# Altium schematic sheet DSS.SchDoc(DSS)
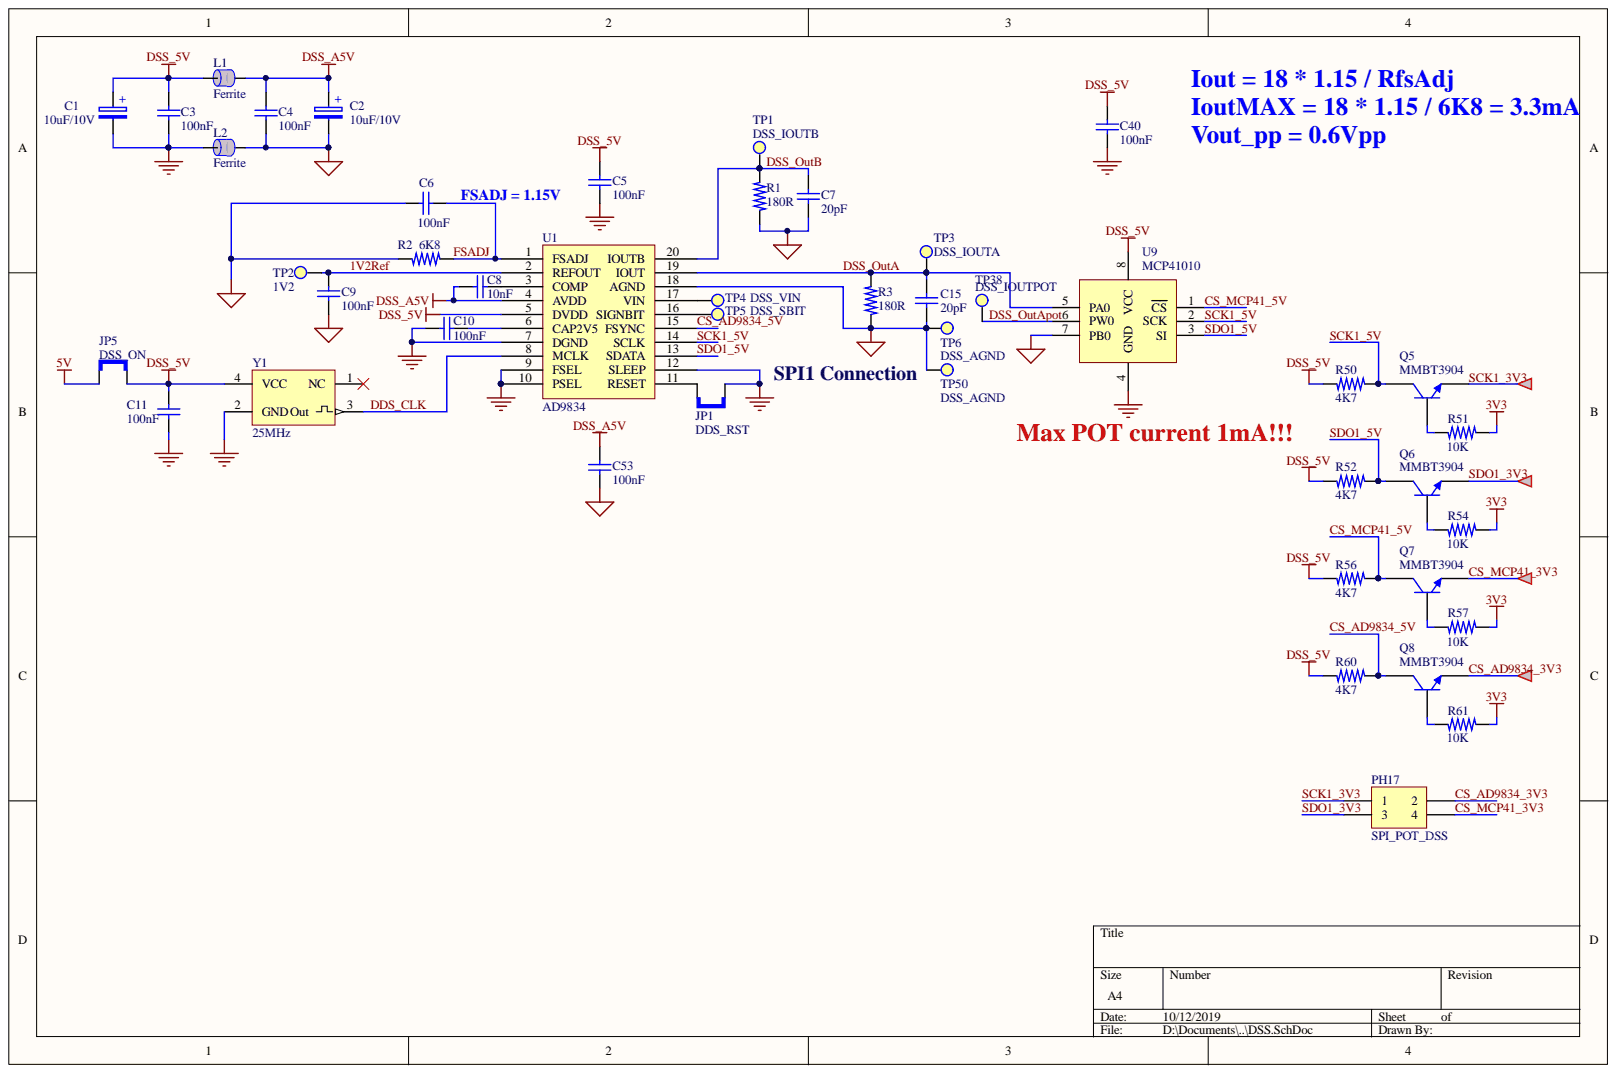
[diagram p0: DSS.SchDoc]

## Parts
C1  10uF/10V  [CAP_RAD_P04_D5x11]
C2  10uF/10V  [CAP_RAD_P04_D5x11]
C3  100nF  [0805Big_C]
C4  100nF  [0805Big_C]
C5  100nF  [0805Big_C]
C6  100nF  [0805Big_C]
C7  20pF  [0805Big_C]
C8  10nF  [0603_C]
C9  100nF  [0603_C]
C10  100nF  [0603_C]
C11  100nF  [0805Big_C]
C15  20pF  [0805Big_C]
C40  100nF  [0603_C]
C53  100nF  [0603_C]
JP1  DDS_RST  [SIP2]
JP5  DSS_ON  [SIP2]
L1  Ferrite  [0805Big_R]
L2  Ferrite  [0805Big_R]
PH17  SPI_POT_DSS  [HDR2X2]
Q5  MMBT3904  [SOT-23]
Q6  MMBT3904  [SOT-23]
Q7  MMBT3904  [SOT-23]
Q8  MMBT3904  [SOT-23]
R1  180R  [0805Big_R]
R2  6K8  [0805Big_R]
R3  180R  [0805Big_R]
R50  4K7  [0805Big_R]
R51  10K  [0805Big_R]
R52  4K7  [0805Big_R]
R54  10K  [0805Big_R]
R56  4K7  [0805Big_R]
R57  10K  [0805Big_R]
R60  4K7  [0805Big_R]
R61  10K  [0805Big_R]
TP1  DSS_IOUTB  [PIN0MM9]
TP2  1V2  [PIN0MM9]
TP3  DSS_IOUTA  [PIN0MM9]
TP4  DSS_VIN  [PIN0MM9]
TP5  DSS_SBIT  [PIN0MM9]
TP6  DSS_AGND  [PIN0MM9]
TP38  DSS_IOUTPOT  [PIN0MM9]
TP50  DSS_AGND  [PIN0MM9]
U1  AD9834  [TSSOP-20]
U9  MCP41010  [DIP-8]
Y1  25MHz  [DIL-8]

## Nets
3V3: R51.1 R54.1 R57.1 R61.1
5V: JP5.1
DSS_5V: C1.1 C3.1 C5.1 C11.1 C40.1 JP5.2 L1.1 R50.2 R52.2 R56.2 R60.2 U1.5(DVDD) U9.8(VCC) Y1.4(VCC)
DSS_A5V: C2.1 C4.1 C8.1 C53.1 L1.2 U1.4(AVDD)
DSS_AGND: C2.2 C4.2 C6.2 C7.2 C9.2 C15.2 C53.2 L2.2 R1.2 R2.1 R3.2 TP6.1 TP50.1 U1.18(AGND) U9.7(PB0)
GND: C1.2 C3.2 C5.2 C10.1 C11.2 C40.2 JP1.1 L2.1 U1.7(DGND) U1.9(FSEL) U1.10(PSEL) U1.12(SLEEP) U9.4(GND) Y1.2
1V2Ref: C9.1 TP2.1 U1.2(REFOUT)
CS_AD9834_3V3: PH17.2 Q8.2
CS_AD9834_5V: Q8.3 R60.1 U1.15(FSYNC)
CS_MCP41_3V3: PH17.4 Q7.2
CS_MCP41_5V: Q7.3 R56.1 U9.1(CS)
DDS_CLK: U1.8(MCLK) Y1.3
DSS_OutA: C15.1 R3.1 TP3.1 U1.19(IOUT) U9.5(PA0)
DSS_OutApot: TP38.1 U9.6(PW0)
DSS_OutB: C7.1 R1.1 TP1.1 U1.20(IOUTB)
FSADJ: C6.1 R2.2 U1.1(FSADJ)
SCK1_3V3: PH17.1 Q5.2
SCK1_5V: Q5.3 R50.1 U1.14(SCLK) U9.2(SCK)
SDO1_3V3: PH17.3 Q6.2
SDO1_5V: Q6.3 R52.1 U1.13(SDATA) U9.3(SI)
NetC8_2: C8.2 U1.3(COMP)
NetC10_2: C10.2 U1.6(CAP2V5)
NetJP1_2: JP1.2 U1.11(RESET)
NetQ5_1: Q5.1 R51.2
NetQ6_1: Q6.1 R54.2
NetQ7_1: Q7.1 R57.2
NetQ8_1: Q8.1 R61.2
NetTP4_1: TP4.1 U1.17(VIN)
NetTP5_1: TP5.1 U1.16(SIGNBIT)

Not connected: Y1 1(NC)

## Library data of the parts
C1 C2 = CapElectr: Capacitor Electrolytic; Simulation: Model Name; Library Name: MyLibrary.IntLib; Component Kind: Standard
C3-C11 C15 C40 C53 = CapCerSMD: Capacitor Ceramic SMD; Simulation: Model Name; Library Name: MyLibrary.IntLib; Component Kind: Standard
JP1 JP5 = JUMPER: Library Name: MyLibrary.IntLib; Description: Jumper; Component Kind: Standard
L1 L2 = FerriteBead: FERRITE CHIP 220 OHM 2000mA; Value: BLM21PG221SN1D; Simulation: Model Name; Library Name: MyLibrary.IntLib; Component Kind: Standard
PH17 = Header 2X2: Header, 2-Pin, Dual row; Library Name: MyLibrary.IntLib; Component Kind: Standard
Q5-Q8 = MMBT3904: NPN Switching Transistor; Simulation: QMMBT3904; Signal Integrity: MMBT3904; Published: 17-Sep-2003; PackageVersion: May-1999; PackageReference: SOT23; PackageDescription: 3-Pin SOT-23 Package 0.95 mm Pitch, 2.3 mm Lead Span; Library Name: MyLibrary.IntLib; LatestRevisionNote: IPC-7351 Footprint Added.; LatestRevisionDate: 12-Dec-2006; DatasheetVersion: 13-May-2002; ComponentLink2URL: http://www.semiconductors.philips.com/acrobat/datasheets/MMBT3904_4.pdf; ComponentLink2Description: Datasheet; ComponentLink1URL: http://www.semiconductors.philips.com/; ComponentLink1Description: Manufacturer Link; Component Kind: Standard; Publisher: Altium Limited
R1-R3 R50-R52 R54 R56 R57 R60 R61 = ResSMD: Resistor SMD; Simulation: Model Name; Library Name: MyLibrary.IntLib; Component Kind: Standard
TP1-TP6 TP38 TP50 = PIN_TEST: Test Point; Library Name: MyLibrary.IntLib; Component Kind: Standard
U1 = AD9834: 75 MHz Complete Direct Digital Synthesis; Library Name: MyComponents.SchLib; Component Kind: Standard
U9 = MCP41010: Potentiometer, Single (10K) with SPI Interface; Library Name: Digital.SchLib; Component Kind: Standard
Y1 = OSCILLATOR: Active Oscillator; Library Name: MyComponents.SchLib; Component Kind: Standard
